# Altium schematic sheet MainBox.SchDoc
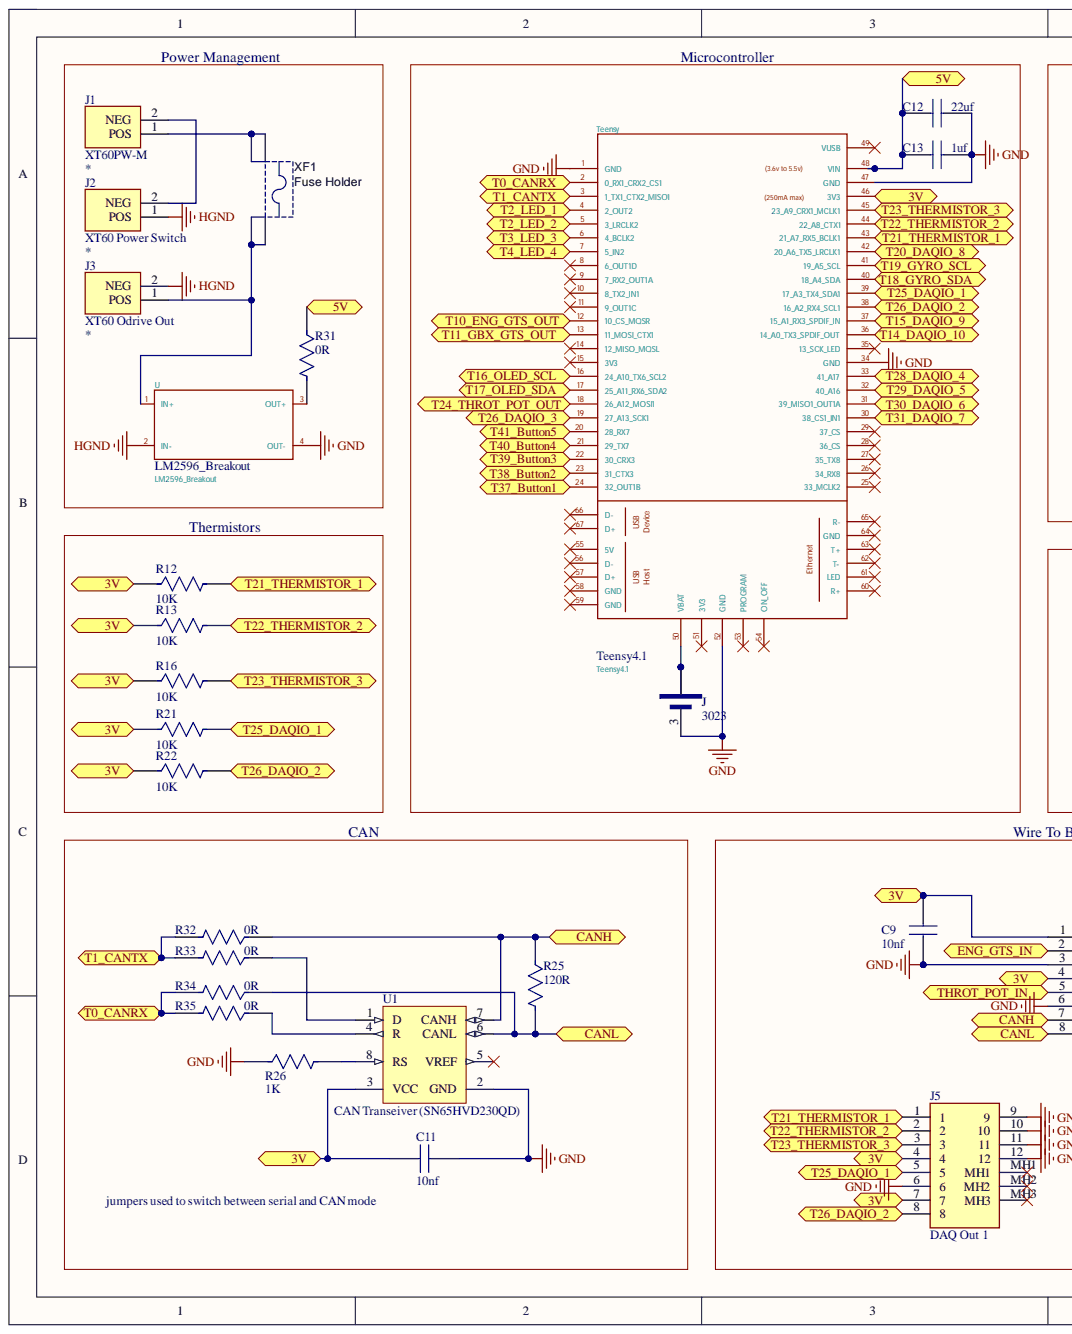
[diagram p0: MainBox.SchDoc, left]
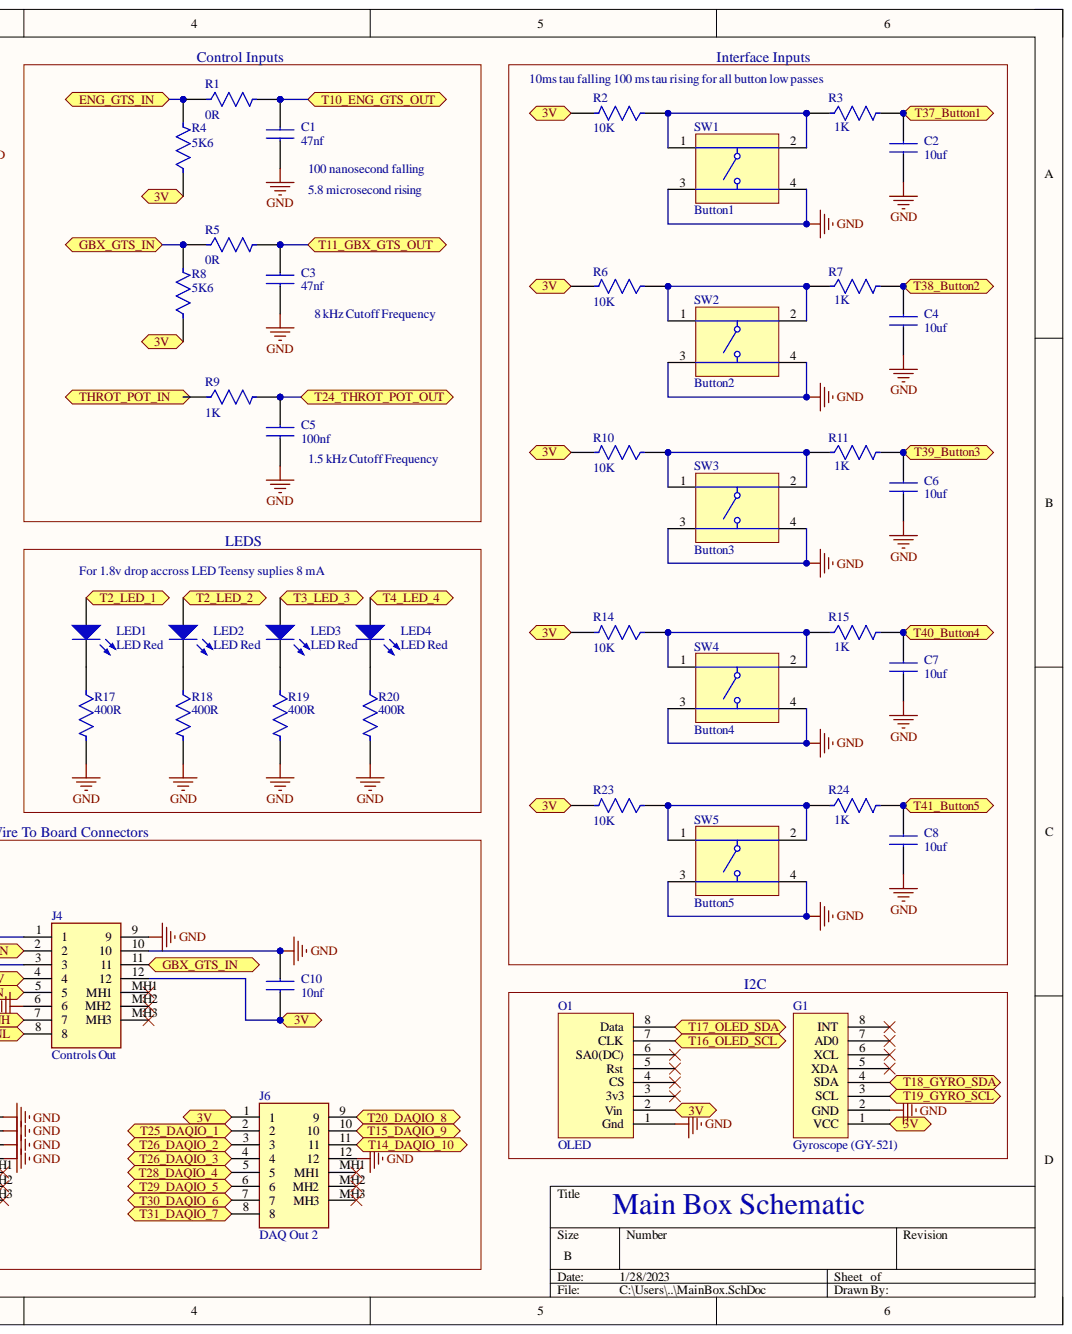
[diagram p1: MainBox.SchDoc, right]

## Parts
C1  47nf  [K104K10X7RF5UH5]
C2  10uf  [K104K10X7RF5UH5]
C3  47nf  [K104K10X7RF5UH5]
C4  10uf  [K104K10X7RF5UH5]
C5  100nf  [K104K10X7RF5UH5]
C6  10uf  [K104K10X7RF5UH5]
C7  10uf  [K104K10X7RF5UH5]
C8  10uf  [K104K10X7RF5UH5]
C9  10nf  [K104K10X7RF5UH5]
C10  10nf  [K104K10X7RF5UH5]
C11  10nf  [K104K10X7RF5UH5]
C12  22uf  [K104K10X7RF5UH5]
C13  1uf  [K104K10X7RF5UH5]
G1  Gyroscope (GY-521)  [Gyroscope (GY-521)-Footprint-1]
J  3023  [KEYSTONE_3023]
J1  XT60PW-M  [AMASS_XT60PW-M]
J2  XT60 Power Switch  [AMASS_XT60PW-M]
J3  XT60 Odrive Out  [AMASS_XT60PW-M]
J4  Controls Out  [DT1312PA]
J5  DAQ Out 1  [DT1312PA]
J6  DAQ Out 2  [DT1312PA]
LED1  LED Red  [Type 5mm]
LED2  LED Red  [Type 5mm]
LED3  LED Red  [Type 5mm]
LED4  LED Red  [Type 5mm]
O1  OLED  [OLED-Footprint-1]
R1  0R  [FP-CFR50J2K2-MFG]
R2  10K  [FP-CFR50J2K2-MFG]
R3  1K  [FP-CFR50J2K2-MFG]
R4  5K6  [FP-CFR50J2K2-MFG]
R5  0R  [FP-CFR50J2K2-MFG]
R6  10K  [FP-CFR50J2K2-MFG]
R7  1K  [FP-CFR50J2K2-MFG]
R8  5K6  [FP-CFR50J2K2-MFG]
R9  1K  [FP-CFR50J2K2-MFG]
R10  10K  [FP-CFR50J2K2-MFG]
R11  1K  [FP-CFR50J2K2-MFG]
R12  10K  [FP-CFR50J2K2-MFG]
R13  10K  [FP-CFR50J2K2-MFG]
R14  10K  [FP-CFR50J2K2-MFG]
R15  1K  [FP-CFR50J2K2-MFG]
R16  10K  [FP-CFR50J2K2-MFG]
R17  400R  [FP-CFR50J2K2-MFG]
R18  400R  [FP-CFR50J2K2-MFG]
R19  400R  [FP-CFR50J2K2-MFG]
R20  400R  [FP-CFR50J2K2-MFG]
R21  10K  [FP-CFR50J2K2-MFG]
R22  10K  [FP-CFR50J2K2-MFG]
R23  10K  [FP-CFR50J2K2-MFG]
R24  1K  [FP-CFR50J2K2-MFG]
R25  120R  [FP-CFR50J2K2-MFG]
R26  1K  [FP-CFR50J2K2-MFG]
R31  0R  [FP-CFR50J2K2-MFG]
R32  0R  [FP-CFR50J2K2-MFG]
R33  0R  [FP-CFR50J2K2-MFG]
R34  0R  [FP-CFR50J2K2-MFG]
R35  0R  [FP-CFR50J2K2-MFG]
SW1  Button1  [TECO-1825910-6_V]
SW2  Button2  [TECO-1825910-6_V]
SW3  Button3  [TECO-1825910-6_V]
SW4  Button4  [TECO-1825910-6_V]
SW5  Button5  [TECO-1825910-6_V]
Teensy  Teensy4.1  [Teensy41]
U  LM2596_Breakout  [LM2596_Breakout]
U1  CAN Transeiver (SN65HVD230QD)  SubFamily: CAN  [PCA82C250]
XF1  Fuse Holder  [KSTN-3557-2]

## Ports
3V, 5V, CANH, CANL, ENG_GTS_IN, GBX_GTS_IN, T0_CANRX, T1_CANTX, T2_LED_1, T2_LED_2, T3_LED_3, T4_LED_4, T10_ENG_GTS_OUT, T11_GBX_GTS_OUT, T14_DAQIO_10, T15_DAQIO_9, T16_OLED_SCL, T17_OLED_SDA, T18_GYRO_SDA, T19_GYRO_SCL, T20_DAQIO_8, T21_THERMISTOR_1, T22_THERMISTOR_2, T23_THERMISTOR_3, T24_THROT_POT_OUT, T25_DAQIO_1, T26_DAQIO_2, T26_DAQIO_3, T28_DAQIO_4, T29_DAQIO_5, T30_DAQIO_6, T31_DAQIO_7, T37_Button1, T38_Button2, T39_Button3, T40_Button4, T41_Button5, THROT_POT_IN

## Nets
GND: C1.1 C2.1 C3.1 C4.1 C5.1 C6.1 C7.1 C8.1 C9.1 C10.2 C11.2 C12.1 C13.1 G1.2(GND) J.3 J4.3 J4.6 J4.9 J4.10 J5.6 J5.9 J5.10 J5.11 J5.12 J6.12 O1.1(Gnd) R17.2 R18.2 R19.2 R20.2 R26.1 SW1.3 SW1.4 SW2.3 SW2.4 SW3.3 SW3.4 SW4.3 SW4.4 SW5.3 SW5.4 Teensy.1(GND) Teensy.34(GND) Teensy.47(GND) Teensy.52(GND) U.4(OUT-) U1.2(GND)
HGND: J2.1 J3.2 U.2(IN-)
3V: C9.2 C10.1 C11.1 G1.1(VCC) J4.1 J4.4 J4.12 J5.4 J5.7 J6.1 O1.2(Vin) R2.2 R4.1 R6.2 R8.1 R10.2 R12.1 R13.1 R14.2 R16.1 R21.1 R22.1 R23.2 Teensy.46(3V3) U1.3(VCC) ; port 3V
5V: C12.2 C13.2 R31.1 Teensy.48(VIN) ; port 5V
CANH: J4.7 R25.1 R32.1 U1.7(CANH) ; port CANH
CANL: J4.8 R25.2 R34.1 U1.6(CANL) ; port CANL
ENG_GTS_IN: J4.2 R1.1 R4.2 ; port ENG_GTS_IN
GBX_GTS_IN: J4.11 R5.1 R8.2 ; port GBX_GTS_IN
T0_CANRX: R34.2 R35.2 Teensy.2(0_RX1_CRX2_CS1) ; port T0_CANRX
T1_CANTX: R32.2 R33.2 Teensy.3(1_TX1_CTX2_MISO1) ; port T1_CANTX
T2_LED_1: LED1.2 Teensy.4(2_OUT2) ; port T2_LED_1
T2_LED_2: LED2.2 Teensy.5(3_LRCLK2) ; port T2_LED_2
T3_LED_3: LED3.2 Teensy.6(4_BCLK2) ; port T3_LED_3
T4_LED_4: LED4.2 Teensy.7(5_IN2) ; port T4_LED_4
T10_ENG_GTS_OUT: C1.2 R1.2 Teensy.12(10_CS_MQSR) ; port T10_ENG_GTS_OUT
T11_GBX_GTS_OUT: C3.2 R5.2 Teensy.13(11_MOSI_CTX1) ; port T11_GBX_GTS_OUT
T14_DAQIO_10: J6.11 Teensy.36(14_A0_TX3_SPDIF_OUT) ; port T14_DAQIO_10
T15_DAQIO_9: J6.10 Teensy.37(15_A1_RX3_SPDIF_IN) ; port T15_DAQIO_9
T16_OLED_SCL: O1.7(CLK) Teensy.16(24_A10_TX6_SCL2) ; port T16_OLED_SCL
T17_OLED_SDA: O1.8(Data) Teensy.17(25_A11_RX6_SDA2) ; port T17_OLED_SDA
T18_GYRO_SDA: G1.4(SDA) Teensy.40(18_A4_SDA) ; port T18_GYRO_SDA
T19_GYRO_SCL: G1.3(SCL) Teensy.41(19_A5_SCL) ; port T19_GYRO_SCL
T20_DAQIO_8: J6.9 Teensy.42(20_A6_TX5_LRCLK1) ; port T20_DAQIO_8
T21_THERMISTOR_1: J5.1 R12.2 Teensy.43(21_A7_RX5_BCLK1) ; port T21_THERMISTOR_1
T22_THERMISTOR_2: J5.2 R13.2 Teensy.44(22_A8_CTX1) ; port T22_THERMISTOR_2
T23_THERMISTOR_3: J5.3 R16.2 Teensy.45(23_A9_CRX1_MCLK1) ; port T23_THERMISTOR_3
T24_THROT_POT_OUT: C5.2 R9.2 Teensy.18(26_A12_MOSI1) ; port T24_THROT_POT_OUT
T25_DAQIO_1: J5.5 J6.2 R21.2 Teensy.39(17_A3_TX4_SDA1) ; port T25_DAQIO_1
T26_DAQIO_2: J5.8 J6.3 R22.2 Teensy.38(16_A2_RX4_SCL1) ; port T26_DAQIO_2
T26_DAQIO_3: J6.4 Teensy.19(27_A13_SCK1) ; port T26_DAQIO_3
T28_DAQIO_4: J6.5 Teensy.33(41_A17) ; port T28_DAQIO_4
T29_DAQIO_5: J6.6 Teensy.32(40_A16) ; port T29_DAQIO_5
T30_DAQIO_6: J6.7 Teensy.31(39_MISO1_OUT1A) ; port T30_DAQIO_6
T31_DAQIO_7: J6.8 Teensy.30(38_CS1_IN1) ; port T31_DAQIO_7
T37_Button1: C2.2 R3.2 Teensy.24(32_OUT1B) ; port T37_Button1
T38_Button2: C4.2 R7.2 Teensy.23(31_CTX3) ; port T38_Button2
T39_Button3: C6.2 R11.2 Teensy.22(30_CRX3) ; port T39_Button3
T40_Button4: C7.2 R15.2 Teensy.21(29_TX7) ; port T40_Button4
T41_Button5: C8.2 R24.2 Teensy.20(28_RX7) ; port T41_Button5
THROT_POT_IN: J4.5 R9.1 ; port THROT_POT_IN
NetJ1_1: J1.1 XF1.1 XF1.2
NetJ1_2: J1.2 J2.2
NetJ3_1: J3.1 U.1(IN+) XF1.3 XF1.4
NetJ_1: J.1 J.2 Teensy.50(VBAT)
NetLED1_1: LED1.1 R17.1
NetLED2_1: LED2.1 R18.1
NetLED3_1: LED3.1 R19.1
NetLED4_1: LED4.1 R20.1
NetR2_1: R2.1 R3.1 SW1.1 SW1.2
NetR6_1: R6.1 R7.1 SW2.1 SW2.2
NetR10_1: R10.1 R11.1 SW3.1 SW3.2
NetR14_1: R14.1 R15.1 SW4.1 SW4.2
NetR23_1: R23.1 R24.1 SW5.1 SW5.2
NetR26_2: R26.2 U1.8(RS)
NetR31_2: R31.2 U.3(OUT+)
NetR33_1: R33.1 U1.1(D)
NetR35_1: R35.1 U1.4(R)

Not connected: G1 5(XDA) 6(XCL) 7(AD0) 8(INT); J4 MH1 MH2 MH3; J5 MH1 MH2 MH3; J6 MH1 MH2 MH3; O1 3(3v3) 4(CS) 5(Rst) 6(SA0(DC)); Teensy 8(6_OUT1D) 9(7_RX2_OUT1A) 10(8_TX2_IN1) 11(9_OUT1C) 14(12_MISO_MQSL) 15(3V3) 25(33_MCLK2) 26(34_RX8) 27(35_TX8) 28(36_CS) 29(37_CS) 35(13_SCK_LED) 49(VUSB) 51(3V3) 53(PROGRAM) 54(ON_OFF) 55(5V) 56(D-) 57(D+) 58(GND) 59(GND) 60(R+) 61(LED) 62(T-) 63(T+) 64(GND) 65(R-) 66(D-) 67(D+); U1 5(VREF)

## Library data of the parts
C1-C13 = CMP-019-000000-1: VISHAY - K104K10X7RF5UH5 - CAP, 0.1F, 50V, 10%, X7R; Geometry.Height: 6.2mm; Arrow Part Number: K104K10X7RF5UH5; Arrow Price/Stock: https://www.arrow.com/en/products/k104k10x7rf5uh5/vishay?region=nac; Component Kind: Standard; Datasheet Link: https://www.vishay.com/docs/45171/kseries.pdf; Design Item ID: K104K10X7RF5UH5; Manufacturer_Name: Vishay; Manufacturer_Part_Number: K104K10X7RF5UH5; Mouser Part Number: 594-K104K10X7RF5UH5; Mouser Price/Stock: https://www.mouser.co.uk/ProductDetail/Vishay-BC-Components/K104K10X7RF5UH5?qs=rLgk8CAOBHbAqIwDswVbzA%3D%3D; Source: K104K10X7RF5UH5.SchLib; Library Name: Bruin Racing Baja
G1 = CMP-010-00024-2: GY-521 with Breakout Module; Component Kind: Standard; Library Name: Bruin Racing Baja
J = CMP-023-000000-1: Battery Retainer Coin, 20.0mm 2 Cell PC Pin; MF: Keystone Electronics; Availability: Unavailable; Component Kind: Standard; Component Type: Standard; Design Item ID: 3023; MP: 3023; Package: None; Pin Count: 3; Price: None; SnapEDA_Link: https://www.snapeda.com/parts/3023/Keystone%20Electronics/view-part/117307/?ref=snap; Source: SnapEDAsch.SchLib; Library Name: Bruin Racing Baja
J1 = CMP-021-000000-2: Availability: Unavailable; Component Kind: Standard; Component Type: Standard; Design Item ID: XT60PW-M; MP: XT60PW-M; Package: None; Pin Count: 2; Price: None; Source: XT60PW-M.SchLib; Library Name: Bruin Racing Baja
J2 J3 = CMP-021-000000-1: MP: XT60PW-M; Availability: Unavailable; Component Kind: Standard; Component Type: Standard; Design Item ID: XT60PW-M; Package: None; Pin Count: 2; Price: None; Source: XT60PW-M.SchLib; Library Name: Bruin Racing Baja
J4-J6 = DT13-12PA: Connector; Manufacturer_Name: TE Connectivity; Arrow Part Number: DT13-12PA; Arrow Price/Stock: https://www.arrow.com/en/products/dt13-12pa/te-connectivity; Component Kind: Standard; Datasheet Link: https://componentsearchengine.com/Datasheets/1/DT13-12PA.pdf; Height: 35.26mm; Manufacturer_Part_Number: DT13-12PA; Mouser Part Number: 571-DT13-12PA; Mouser Price/Stock: https://www.mouser.co.uk/ProductDetail/TE-Connectivity-DEUTSCH/DT13-12PA?qs=vkMqrgFpRahFscUAzs597g%3D%3D
LED1-LED4 = CMP-1488-00009-1: THT LED round mono-color color lens, WL-TMRW, Blue; Manufacturer: Wuerth Elektronik; PartNumber: 151051BS04000; Component Kind: Standard; ComponentLink1Description: Manufacturer URL; ComponentLink1URL: http://www.we-online.com; ComponentLink2Description: Datasheet; ComponentLink2URL: http://katalog.we-online.de/pbs/datasheet/7448042001.pdfhttp://katalog.we-online.de/pbs/datasheet/7448042001.pdfhttp://katalog.we-online.de/pbs/datasheet/7448042001.pdfhttp://katalog.we-online.de/pbs/datasheet/151051BS04000.pdf; Emitting color: Blue; Library Name: Altium Content Vault; PackageReference: Type 5mm
O1 = CMP-015-00008-5: Monochrome 0.96" 128x64 OLED Graphic Display - STEMMA QT; Component Kind: Standard; Library Name: Bruin Racing Baja
R1-R26 R31-R35 = CMP-03212-000011-1: Max Power Dissipation: 500mW; Number of Resistors: 1; Resistance: 2.2kR; Resistance Tolerance: 5%; Temperature Coefficient: 0ppm/°C; Voltage Rating: 350V; Case/Package: Axial; Component Kind: Standard; Diameter: 3mm; ELV: Compliant; Length: 9mm; Library Name: Altium Content Vault; Max Operating Temperature: 155°C; Min Operating Temperature: -55°C; Mount: Axial; Number of Terminations: 2; Package Quantity: 3000; Packaging: Cut Tape; Power Rating: 500mW; Radiation Hardening: No; REACH SVHC: No SVHC; Resistor Type: Thin Film; RoHS Compliant: Yes; Series: CFR50, Neohm; Technology: CARBON FILM; Termination: Axial; Tolerance: 5%; Voltage Rating (DC): 350V
SW1-SW5 = CMP-1684-00021-1: Tact Switch, SPST-NO, 0.05 A, -35 to 85 degC, 4-Pin THD, RoHS, Bulk; Manufacturer: TE Connectivity; Part No: 1825910-6; Actuator Color: Black; Actuator Length: 1.4mm; Actuator Material: PolybutyleneTerephthalate; Actuator Style: RoundButton; Body Orientation: Straight; Bushing Type: Unthreaded; Contact Rating @ Voltage: 0.05A@24VDC; Dielectric Strength: 500VAC; Electrical Life: 100000Cycles; Function: MomentaryContact; Illumination: N/R; Maximum Contact Resistance: 100mOhm; Maximum DC Voltage Rating: 24V; Pole Throw Configuration: SPST; Switch Configuration: OFF(ON); Base Material: N/A; Component Kind: Standard; Contact Material: CopperAlloy; Contact Plating: Silver; Datasheet URL: http://www.te.com/commerce/DocumentDelivery/DDEController?Action=showdoc&DocId=Customer+Drawing%7F1825910%7FC9%7Fpdf%7FEnglish%7FENG_CD_1825910_C9.pdf%7F1825910-6; Datasheet Version: Rev. C9; Dimension: 5.99x5mm; Family: 1825910; Housing Material: N/A; Library Name: Altium Content Vault; Manufacturer URL: http://www.te.com; Maximum AC Voltage Rating: N/A; Mechanical Life: 100000Cycles; Minimum Insulation Resistance: 100MOhm; Mounting: ThroughHole; Operating Temperature: -35to85C; Package Description: 4-Pin Through Hole Device, Body 5.99 x 5.99 mm, Pitch 6.5 mm; Package Reference: THD; Packaging: N/A; Product Weight: N/A; RoHS: Yes; Seal Description: N/A; Seal Location: N/A; Terminal Type: PCPins; Thread Size: N/R
Teensy = CMP-011-000007-3: Component Kind: Standard; Design Item ID: Teensy4.1; Source: teensy.SchLib; Value: Teensy4.1; Library Name: Bruin Racing Baja
U = CMP-013-000002-3: Component Kind: Standard; Design Item ID: LM2596_Breakout; Value: LM2596_Breakout; Library Name: Bruin Racing Baja; Source: baja.SchLib
U1 = CMP-0315-00028-2: Automotive Catalog 3.3 V CAN Transceiver, 17 mA, -40 to 125 degC, 8-pin SOIC (D), Green (RoHS & no Sb/Br); Manufacturer: Texas Instruments; Drivers Per Package: 1; ICC(Max)(mA): 17; Supply Voltage(s)(V): 3.3; Transient Pulse Protection(V): -25 to 25; Code_JEDEC: MS-012AA; Component Kind: Standard; ComponentLink1Description: Datasheet; ComponentLink1URL: http://www.ti.com/lit/ds/symlink/sn65hvd230.pdf; DatasheetVersion: SLOS346K; Eco-Plan: Green (RoHS & no Sb/Br); Isolated: No; Library Name: Altium Content Vault; Mounting Technology: Surface Mount; PackageDescription: 8-Pin Plastic Small Outline Integrated Circuit, 4.9 x 3.9 mm, Pitch 1.27 mm Pitch; PackageReference: D0008A; PackageVersion: revM, Jun-2011; PartNumber: SN65HVD230QD; Rating: Catalog; Receivers Per Package: 1
XF1 = CMP-2000-05461-1: FUSE HOLDER, PCB MOUNT, 500VAC, 20A, ROHS; Manufacturer: Keystone-Electronics; Current Rating: 30A; For Use With/Related Products: ATC,ATO257,MINI897; Fuse Size: 0.43"Lx0.15"Wx0.64"H(10.92mmx3.81mmx16.25mm); Fuse Type: Blade; Fuseholder Type: Block; Number of Circuits: 1; Voltage: 500V; Category: CircuitProtection; Component Kind: Standard; Contact Finish: TinNickel; Contact Material: Brass; Datasheet URL: http://datasheet.octopart.com/3557-2-Keystone-datasheet-15715937.pdf; Datasheet Version: Apr-13; Family: Fuseholders; Library Name: Altium Content Vault; Manufacturer URL: http://www.keyelco.com/; Mounting Type: PCB; Orientation: Vertical; Series: -; Termination Style: PCPin
